# Altium schematic sheet CAN_RPi.SchDoc
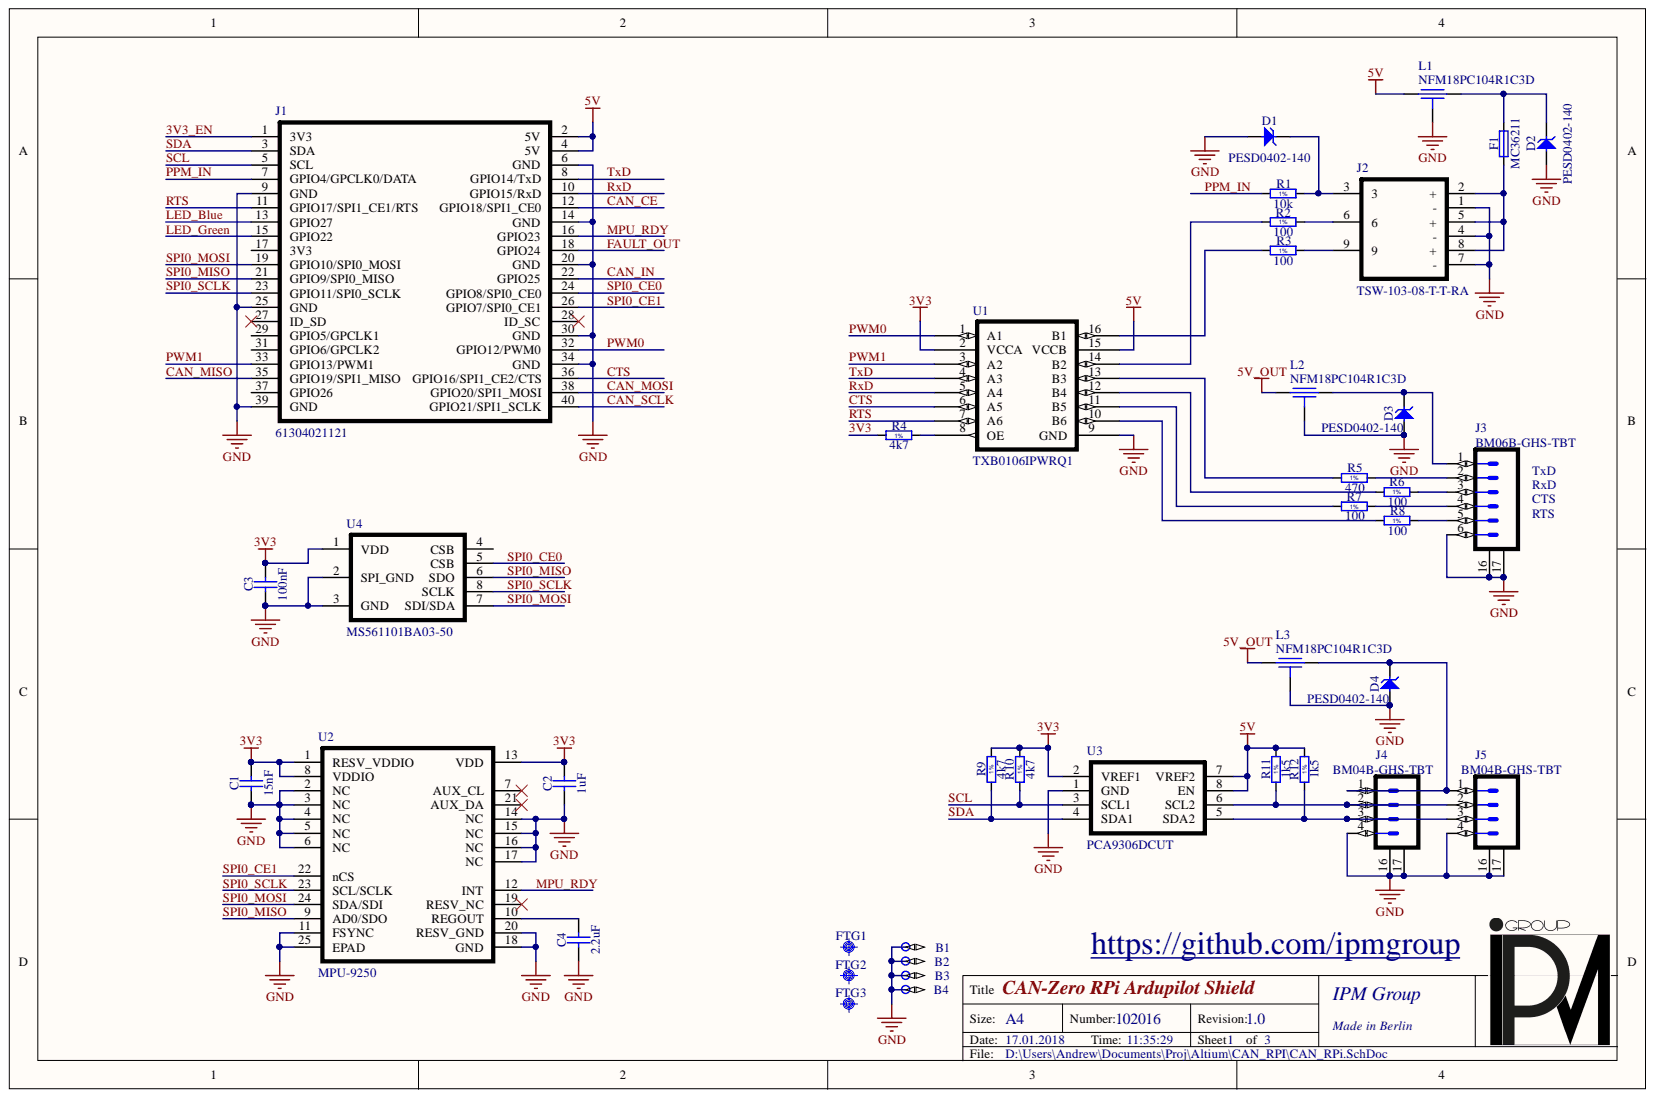
[diagram p0: CAN_RPi.SchDoc]

## Parts
B1  Plated Hole  [MTG270_500]  (value not drawn)  (no BOM)
B2  Plated Hole  [MTG270_500]  (value not drawn)  (no BOM)
B3  Plated Hole  [MTG270_500]  (value not drawn)  (no BOM)
B4  Plated Hole  [MTG270_500]  (value not drawn)  (no BOM)
C1  15nF  [CAPC1005X55N]
C2  1uF  [CAPC1005X55N]
C3  100nF  [CAPC1005X55N]
C4  2.2uF  [CAPC1005X55N]
D1  PESD0402-140  [0402]
D2  PESD0402-140  [0402]
D3  PESD0402-140  [0402]
D4  PESD0402-140  [0402]
F1  MC36211  [FUSR_LITTELFUSE_1206L012]
FTG1  Use only in PCB  [FIDUCIAL_TOP_C100-200]  (value not drawn)  (no BOM)
FTG2  Use only in PCB  [FIDUCIAL_TOP_C100-200]  (value not drawn)  (no BOM)
FTG3  Use only in PCB  [FIDUCIAL_TOP_C100-200]  (value not drawn)  (no BOM)
J1  61304021121  [61304021121_RPi]
J2  TSW-103-08-T-T-RA  [HDR3X3H]
J3  BM06B-GHS-TBT  [JST_BM06B]
J4  BM04B-GHS-TBT  [JST_BM04B]
J5  BM04B-GHS-TBT  [JST_BM04B]
L1  NFM18PC104R1C3D  [L_NFM18PC]
L2  NFM18PC104R1C3D  [L_NFM18PC]
L3  NFM18PC104R1C3D  [L_NFM18PC]
R1  10k  [RESC1005X40N]
R2  100  [RESC1005X40N]
R3  100  [RESC1005X40N]
R4  4k7  [RESC1005X40N]
R5  470  [RESC1005X40N]
R6  100  [RESC1005X40N]
R7  100  [RESC1005X40N]
R8  100  [RESC1005X40N]
R9  4k7  [RESC1005X40N]
R10  4k7  [RESC1005X40N]
R11  1k5  [RESC1005X40N]
R12  1k5  [RESC1005X40N]
U1  TXB0106IPWRQ1  [PW16]
U2  MPU-9250  [QFN40P300X300X105_HS-25M]
U3  PCA9306DCUT  [DCU8]
U4  MS561101BA03-50  [SON125P300X110-8N]

## Nets
5V: J1.2(5V) J1.4(5V) L1.1 R11.2 R12.2 U1.15(VCCB) U3.7(VREF2) U3.8(EN)
5V_OUT: L2.1 L3.1
GND: B1.1 B2.1 B3.1 B4.1 C1.1 C2.1 C3.1 C4.1 D1.2 D2.2 D3.2 D4.2 J1.6(GND) J1.9(GND) J1.14(GND) J1.20(GND) J1.25(GND) J1.30(GND) J1.34(GND) J1.39(GND) J2.1(-) J2.4(-) J2.7(-) J3.6 J3.16 J3.17 J4.4 J4.16 J4.17 J5.4 J5.16 J5.17 L1.3 L2.3 L3.3 U1.9(GND) U2.2(NC) U2.3(NC) U2.4(NC) U2.5(NC) U2.6(NC) U2.11(FSYNC) U2.14(NC) U2.15(NC) U2.16(NC) U2.17(NC) U2.18(GND) U2.20(RESV_GND) U2.25(EPAD) U3.1(GND) U4.2(SPI_GND) U4.3(GND)
3V3: C1.2 C2.2 C3.2 R4.1 R9.2 R10.2 U1.2(VCCA) U2.1(RESV_VDDIO) U2.8(VDDIO) U2.13(VDD) U3.2(VREF1) U4.1(VDD)
3V3_EN: J1.1(3V3)
CAN_CE: J1.12(GPIO18/SPI1_CE0)
CAN_IN: J1.22(GPIO25)
CAN_MISO: J1.35(GPIO19/SPI1_MISO)
CAN_MOSI: J1.38(GPIO20/SPI1_MOSI)
CAN_SCLK: J1.40(GPIO21/SPI1_SCLK)
CTS: J1.36(GPIO16/SPI1_CE2/CTS) U1.6(A5)
FAULT_OUT: J1.18(GPIO24)
LED_Blue: J1.13(GPIO27)
LED_Green: J1.15(GPIO22)
MPU_RDY: J1.16(GPIO23) U2.12(INT)
PPM_IN: J1.7(GPIO4/GPCLK0/DATA) R1.1
PWM0: J1.32(GPIO12/PWM0) U1.1(A1)
PWM1: J1.33(GPIO13/PWM1) U1.3(A2)
RTS: J1.11(GPIO17/SPI1_CE1/RTS) U1.7(A6)
RxD: J1.10(GPIO15/RxD) U1.5(A4)
SCL: J1.5(SCL) R10.1 U3.3(SCL1)
SDA: J1.3(SDA) R9.1 U3.4(SDA1)
SPI0_CE0: J1.24(GPIO8/SPI0_CE0) U4.5(CSB)
SPI0_CE1: J1.26(GPIO7/SPI0_CE1) U2.22(nCS)
SPI0_MISO: J1.21(GPIO9/SPI0_MISO) U2.9(AD0/SDO) U4.6(SDO)
SPI0_MOSI: J1.19(GPIO10/SPI0_MOSI) U2.24(SDA/SDI) U4.7(SDI/SDA)
SPI0_SCLK: J1.23(GPIO11/SPI0_SCLK) U2.23(SCL/SCLK) U4.8(SCLK)
TxD: J1.8(GPIO14/TxD) U1.4(A3)
NetC4_2: C4.2 U2.10(REGOUT)
NetD1_1: D1.1 J2.3 R1.2
NetD2_1: D2.1 F1.2 L1.2
NetD3_1: D3.1 J3.1 L2.2
NetD4_1: D4.1 J4.1 J5.1 L3.2
NetF1_1: F1.1 J2.2(+) J2.5(+) J2.8(+)
NetJ2_6: J2.6 R2.2
NetJ2_9: J2.9 R3.2
NetJ3_2: J3.2 R5.2
NetJ3_3: J3.3 R6.2
NetJ3_4: J3.4 R7.2
NetJ3_5: J3.5 R8.2
NetJ4_2: J4.2 J5.2 R11.1 U3.6(SCL2)
NetJ4_3: J4.3 J5.3 R12.1 U3.5(SDA2)
NetR2_1: R2.1 U1.14(B2)
NetR3_1: R3.1 U1.16(B1)
NetR4_2: R4.2 U1.8(OE)
NetR5_1: R5.1 U1.13(B3)
NetR6_1: R6.1 U1.12(B4)
NetR7_1: R7.1 U1.11(B5)
NetR8_1: R8.1 U1.10(B6)

Not connected: J1 17(3V3) 27(ID_SD) 28(ID_SC) 29(GPIO5/GPCLK1) 31(GPIO6/GPCLK2) 37(GPIO26); U2 7(AUX_CL) 19(RESV_NC) 21(AUX_DA); U4 4(CSB)

## Library data of the parts
B1-B4 = PLATED_HOLE2.7_PAD5.0: Single Terminal Socket; Part Description: Plated Through Hole: Hole Dia.=2.7mm Pad Dia.=5.0mm; Database Table Name: Pads; Mounted: No; ComponentHeight: 0mm; Component Type: Standard (No BOM); Family: Plated Hole; Library Name: Eletro-mechanical.DbLib; PackageDescription: Plated Through Hole: Hole Dia.=2.7mm Pad Dia.=5.0mm; Part Number: PLATED_HOLE2.7_PAD5.0; Pin Count: 1; SMD: No; Supplier 1: Farnell; Supplier 2: RSComponents; Supplier 3: Digi-Key; Author: CERN DEM JMW
C1 = CC0402_15NF_16V_10%_X7R: Non-polarised capacitor; Manufacturer: GENERIC; Manufacturer1 Part Number: MC0402B153K160CT; Part Description: SMD Multilayer Chip Ceramic Capacitor; Database Table Name: Capacitors SMD; TC: X7R; Tolerance: ±10%; Voltage: 16V; Case: 0402; Component Kind: Standard; Component Type: Standard; Library Name: Capacitors.DbLib; Manufacturer1 ComponentHeight: 0.55mm; Manufacturer1 Example: MULTICOMP; Manufacturer Part Number: CC0402_15NF_16V_10%_X7R; Mounted: Yes; Part Number: CC0402_15NF_16V_10%_X7R; Pin Count: 2; SMD: Yes; Supplier 1: Farnell; Supplier 2: RSComponents; Supplier 3: Digi-Key; Supplier Part Number 1: 1758887RL; Value: 15nF; Author: CERN DEM JLC
C2 = CC0402_1UF_16V_10%_X5R: Non-polarised capacitor; Manufacturer: GENERIC; Manufacturer1 Part Number: GRM155C80J105KE15D; Manufacturer Part Number: CC0402_1UF_6.3V_10%_X5R; Part Description: SMD Multilayer Chip Ceramic Capacitor; Database Table Name: Capacitors SMD; TC: X5R; Tolerance: ±10%; Voltage: 6.3V; Case: 0402; Component Kind: Standard; Component Type: Standard; Library Name: Capacitors.DbLib; Manufacturer1 ComponentHeight: 0.55mm; Manufacturer1 Example: MURATA; Mounted: Yes; Part Number: CC0402_1UF_6.3V_10%_X5R; Pin Count: 2; SMD: Yes; Supplier 1: Farnell; Supplier 2: RSComponents; Supplier 3: Digi-Key; Value: 1uF; Author: CERN DEM JLC
C3 = CC0402_100NF_16V_10%_X7R: Non-polarised capacitor; Manufacturer: GENERIC; Manufacturer1 Part Number: GRM155R71C104KA88D; Part Description: SMD Multilayer Chip Ceramic Capacitor; Database Table Name: Capacitors SMD; TC: X7R; Tolerance: ±10%; Voltage: 16V; Case: 0402; Component Kind: Standard; Component Type: Standard; Library Name: Capacitors.DbLib; Manufacturer1 ComponentHeight: 0.55mm; Manufacturer1 Example: MURATA; Manufacturer Part Number: CC0402_100NF_16V_10%_X7R; Mounted: Yes; Part Number: CC0402_100NF_16V_10%_X7R; Pin Count: 2; SMD: Yes; Supplier 1: Farnell; Supplier 2: RSComponents; Supplier 3: Digi-Key; Value: 100nF; Author: CERN DEM JLC
C4 = CC0402_2.2UF_6.3V_10%_X5R: Non-polarised capacitor; Manufacturer: GENERIC; Manufacturer1 Part Number: GRM155R60J225ME95D; Part Description: SMD Multilayer Chip Ceramic Capacitor; Database Table Name: Capacitors SMD; TC: X5R; Tolerance: ±10%; Voltage: 6.3V; Case: 0402; Component Kind: Standard; Component Type: Standard; Library Name: Capacitors.DbLib; Manufacturer1 ComponentHeight: 0.55mm; Manufacturer1 Example: MURATA; Manufacturer Part Number: CC0402_2.2UF_6.3V_10%_X5R; Mounted: Yes; Part Number: CC0402_2.2UF_6.3V_10%_X5R; Pin Count: 2; SMD: Yes; Supplier 1: Farnell; Supplier 2: RSComponents; Supplier 3: Digi-Key; Supplier Part Number 1: 2362091; Value: 2.2uF; Author: CERN DEM JMW
D1-D4 = PESD5V0S1UA: Transient Voltage Suppressor Diode (Uni-Directional); Manufacturer: TE CONNECTIVITY / RAYCHEM; Part Description: Unidirectional ESD Protection for Transient Voltage Suppression; Database Table Name: Diodes; Power: 890W; Voltage: 14V; Case: 0402; ComponentHeight: 1.1mm; Component Kind: Standard; Component Type: Standard; Library Name: ICs And Semiconductors.DbLib; Manufacturer1 Example: TE CONNECTIVITY / RAYCHEM; Manufacturer1 Part Number: PESD0402-140; Manufacturer Part Number: PESD0402-140; Mounted: Yes; PackageDescription: Small Outline Diode Flat Lead (SOD-323), 1.70mm W X 1.25mm L X 1.10mm H body,  IPC Medium Density; Part Number: PESD0402-140; Pin Count: 2; SMD: Yes; Supplier 1: Farnell; Supplier 2: RSComponents; Supplier 3: Digi-Key; Author: CERN DEM JLC
F1 = FUSR_LITTELFUSE_1206L012: Fuse; Manufacturer: MULTICOPM; Part Description: POLYFUSE® Surface Mount Resettable PTC; Part Number: FUSR_MULTICOPM_MC36211; Database Table Name: Fuses; Value: 350mA-16V; Case: 3518; ComponentHeight: 1.45mm; Component Kind: Standard; Component Type: Standard; Family: Fuses Resettable; Library Name: Eletro-mechanical.DbLib; Manufacturer1 Example: MULTICOPM; Manufacturer1 Part Number: MC36211; Manufacturer Part Number: MC36211; Mounted: Yes; PackageDescription: Surface Mount POLY-FUSE® Resettable PTC, 1206L Series; Pin Count: 2; SMD: Yes; Supplier 1: Farnell; Supplier 2: RSComponents; Supplier 3: Digi-Key; Supplier Part Number 1: 1861188; Author: CERN DEM JLC
FTG1-FTG3 = FIDUCIAL_TARGET_C100-200: Fiducial Target; Part Description: Round Fiducial Target, Top Layer, 1.0mm, Top Solder 2.0mm, Keepout =2.0mm; Database Table Name: Pads; Mounted: No; ComponentHeight: 0mm; Component Type: Standard (No BOM); Family: Fiducial; Library Name: Eletro-mechanical.DbLib; PackageDescription: Round Fiducial Target, Top Layer, 1.0mm, Top Solder 2.0mm, Keepout =2.0mm; Part Number: FIDUCIAL_TARGET_C100-200; Pin Count: 1; SMD: Yes; Supplier 1: Farnell; Supplier 2: RSComponents; Supplier 3: Digi-Key; Author: CERN DEM JLC
J1 = Header RPi: WR-PHD 2.54 mm THT Dual Pin Header, 40p; Manufacturer: Wurth Elektronik; Component Kind: Standard; Component Type: Standard; Library Name: My_PCB.SchLib; Manufacturer1 Example: Wurth Elektronik; Manufacturer1 Part Number: 61304021121; Manufacturer Part Number: 61304021121; Mounted: Yes; Part Description: WR-PHD 2.54 mm THT Dual Pin Header, 40p; Part Number: 61304021121; Pin Count: 40; SMD: Yes; Supplier 1: Farnell; Supplier 2: RSComponents; Supplier 3: Digi-Key
J2 = Header 3X3H: Header, 9-Pin, Right Angle; Manufacturer: SAMTEC; Part Description: THROUGH-HOLE .025" SQ POST HEADER; Component Kind: Standard; Component Type: Standard; Library Name: My_PCB.SchLib; Manufacturer1 Example: SAMTEC; Manufacturer1 Part Number: TSW-103-08-T-T-RA; Manufacturer Part Number: TSW-103-08-T-T-RA; Mounted: Yes; Part Number: TSW-103-08-T-T-RA; Pin Count: 9; SMD: Yes; Supplier 1: Farnell; Supplier 2: RSComponents; Supplier 3: Digi-Key; Supplier Part Number 1: 2053187
J3 = (no symbol name): Connector 6 Male; Manufacturer: JST; Part Description: 6 Contacts - Pitch 1.25mm; ComponentHeight: 4.05.mm; Component Kind: Standard; Component Type: Standard; Family: Header 6; Library Name: My_PCB.SchLib; Manufacturer1 Example: JST; Manufacturer1 Part Number: BM06B-GHS-TBT; Manufacturer Part Number: BM06B-GHS-TBT; Mounted: Yes; Part Number: BM06B-GHS-TBT; Pin Count: 6; SMD: Yes; Supplier 1: Farnell; Supplier 2: RSComponents; Supplier 3: Digi-Key; Supplier Part Number 2: 752-1771; Author: CERN DEM JLC
J4 J5 = JST_GH_BM04: Connector 4 Male; Manufacturer: JST; Part Description: 4 Contacts - Pitch 1.25mm; ComponentHeight: 4.05.mm; Component Kind: Standard; Component Type: Standard; Family: Header 4; Library Name: My_PCB.SchLib; Manufacturer1 Example: JST; Manufacturer1 Part Number: BM04B-GHS-TBT; Manufacturer Part Number: BM04B-GHS-TBT; Mounted: Yes; Part Number: BM04B-GHS-TBT; Pin Count: 4; SMD: Yes; Supplier 1: Farnell; Supplier 2: RSComponents; Supplier 3: Digi-Key; Supplier Part Number 2: 752-1769; Author: CERN DEM JLC
L1-L3 = L_EMI: Capacitor; Manufacturer: MURATA; Part Description: EMI Suppression Filters; RatedCurrent: 2A; Value: 100nF; Case: 0603; Component Kind: Standard; Component Type: Standard; Library Name: My_PCB.SchLib; Manufacturer1 Example: MURATA; Manufacturer1 Part Number: NFM18PC104R1C3D; Manufacturer Part Number: NFM18PC104R1C3D; Mounted: Yes; Part Number: NFM18PC104R1C3D; Pin Count: 4; SMD: Yes; Supplier 1: Farnell; Supplier 2: RSComponents; Supplier 3: Digi-Key; Supplier Part Number 1: 1686508
R1 = R0402_10K_1%_0.0625W_100PPM: Resistor - 1%; Manufacturer: GENERIC; Manufacturer1 Part Number: 232270671003L; Part Description: General Purpose Thick Film Chip Resistor; Database Table Name: Resistors SMD; TC: ±100ppm/°C; Case: 0402; Component Kind: Standard; Component Type: Standard; Library Name: Resistors.DbLib; Manufacturer1 ComponentHeight: 0.4mm; Manufacturer1 Example: YAGEO PHYCOMP; Manufacturer Part Number: R0402_10K_1%_0.0625W_100PPM; Mounted: Yes; Part Number: R0402_10K_1%_0.0625W_100PPM; Pin Count: 2; Power: 0.0625W; SMD: Yes; Supplier 1: Farnell; Supplier 2: RSComponents; Supplier 3: Digi-Key; Tolerance: ±1%; Value: 10k; Author: CERN DEM JLC
R2 R3 R6-R8 = R0402_100R_1%_0.0625W_100PPM: Resistor - 1%; Manufacturer: GENERIC; Manufacturer1 Part Number: MCWR04X1000FTL; Part Description: General Purpose Thick Film Chip Resistor; Database Table Name: Resistors SMD; TC: ±100ppm/°C; Case: 0402; Component Kind: Standard; Component Type: Standard; Library Name: Resistors.DbLib; Manufacturer1 ComponentHeight: 0.4mm; Manufacturer1 Example: MULTICOMP; Manufacturer Part Number: R0402_100R_1%_0.0625W_100PPM; Mounted: Yes; Part Number: R0402_100R_1%_0.0625W_100PPM; Pin Count: 2; Power: 0.0625W; SMD: Yes; Supplier 1: Farnell; Supplier 2: RSComponents; Supplier 3: Digi-Key; Supplier Part Number 1: 2447095; Tolerance: ±1%; Value: 100; Author: CERN DEM JLC
R4 R9 R10 = R0402_4K7_1%_0.0625W_100PPM: Resistor - 1%; Manufacturer: GENERIC; Manufacturer1 Part Number: 232270674702L; Part Description: General Purpose Thick Film Chip Resistor; Database Table Name: Resistors SMD; TC: ±100ppm/°C; Case: 0402; Component Kind: Standard; Component Type: Standard; Library Name: Resistors.DbLib; Manufacturer1 ComponentHeight: 0.4mm; Manufacturer1 Example: YAGEO PHYCOMP; Manufacturer Part Number: R0402_4K7_1%_0.0625W_100PPM; Mounted: Yes; Part Number: R0402_4K7_1%_0.0625W_100PPM; Pin Count: 2; Power: 0.0625W; SMD: Yes; Supplier 1: Farnell; Supplier 2: RSComponents; Supplier 3: Digi-Key; Tolerance: ±1%; Value: 4k7; Author: CERN DEM JLC
R5 = R0402_470R_1%_0.0625W_100PPM: Resistor - 1%; Manufacturer: GENERIC; Manufacturer1 Part Number: 232270674701L; Part Description: General Purpose Thick Film Chip Resistor; Database Table Name: Resistors SMD; TC: ±100ppm/°C; Case: 0402; Component Kind: Standard; Component Type: Standard; Library Name: Resistors.DbLib; Manufacturer1 ComponentHeight: 0.4mm; Manufacturer1 Example: YAGEO PHYCOMP; Manufacturer Part Number: R0402_470R_1%_0.0625W_100PPM; Mounted: Yes; Part Number: R0402_470R_1%_0.0625W_100PPM; Pin Count: 2; Power: 0.0625W; SMD: Yes; Supplier 1: Farnell; Supplier 2: RSComponents; Supplier 3: Digi-Key; Tolerance: ±1%; Value: 470; Author: CERN DEM JLC
R11 R12 = R0402_1K5_1%_0.0625W_100PPM: Resistor - 1%; Manufacturer: GENERIC; Manufacturer1 Part Number: 232270671502L; Part Description: General Purpose Thick Film Chip Resistor; Database Table Name: Resistors SMD; TC: ±100ppm/°C; Case: 0402; Component Kind: Standard; Component Type: Standard; Library Name: Resistors.DbLib; Manufacturer1 ComponentHeight: 0.4mm; Manufacturer1 Example: YAGEO PHYCOMP; Manufacturer Part Number: R0402_1K5_1%_0.0625W_100PPM; Mounted: Yes; Part Number: R0402_1K5_1%_0.0625W_100PPM; Pin Count: 2; Power: 0.0625W; SMD: Yes; Supplier 1: Farnell; Supplier 2: RSComponents; Supplier 3: Digi-Key; Tolerance: ±1%; Value: 1k5; Author: CERN DEM JLC
U1 = TXB0106IPWRQ1: 6-BIT  BIDIRECTIONAL  VOLTAGE-LEVEL  TRANSLATOR; Manufacturer: Texas Instruments; Manufacturer1 Part Number: TXB0106PWR; Case: TSSOP; Component Kind: Standard; Component Type: Standard; Library Name: My_PCB.SchLib; Manufacturer1 Example: Texas Instruments; Manufacturer Part Number: TXB0106PWR; Mounted: Yes; Part Description: 6-Bit Bidirectional; Part Number: TXB0106PWR; Pin Count: 16; SMD: Yes; Supplier 1: Farnell; Supplier 2: RSComponents; Supplier 3: Digi-Key; Supplier Part Number 1: 1694679
U2 = MPU-9250: Accelerometer, Gyroscope, Magnetometer, 3 Axis Sensor I²C, SPI Output; Manufacturer: invensense; Case: QFN; Component Kind: Standard; Component Type: Standard; Library Name: My_PCB.SchLib; Manufacturer1 Example: invensense; Manufacturer1 Part Number: MPU-9250; Manufacturer Part Number: MPU-9250; Mounted: Yes; Part Description: Accelerometer, Gyroscope, Magnetometer, 3 Axis Sensor I²C, SPI Output; Part Number: MPU-9250; Pin Count: 24; SMD: Yes; Supplier 1: Farnell; Supplier 2: RSComponents; Supplier 3: Digi-Key; Supplier Part Number 2: 883-7942
U3 = PCA9306DCUT: Dual Bidirectional  I2C Bus and SMBus  Voltage-Level  Translator; Manufacturer: Texas Instruments; Case: US8; Component Kind: Standard; Component Type: Standard; Library Name: My_PCB.SchLib; Manufacturer1 Example: Texas Instruments; Manufacturer1 Part Number: PCA9306DCUT; Manufacturer Part Number: PCA9306DCUT; Mounted: Yes; Part Description: Dual Bidirectional; Part Number: PCA9306DCUT; Pin Count: 8; SMD: Yes; Supplier 1: Farnell; Supplier 2: RSComponents; Supplier 3: Digi-Key; Supplier Part Number 1: 1053573; Supplier Part Number 2: 662-7421
U4 = MS561101BA03-50: Barometric Pressure Sensor; Manufacturer: MEASUREMENT SPECIALTIES; Case: QFN; Component Kind: Standard; Component Type: Standard; Library Name: My_PCB.SchLib; Manufacturer1 Example: MEASUREMENT SPECIALTIES; Manufacturer1 Part Number: MS561101BA03-50; Manufacturer Part Number: MS561101BA03-50; Mounted: Yes; Part Description: Barometric Pressure Sensor; Part Number: MS561101BA03-50; Pin Count: 8; SMD: Yes; Supplier 1: Farnell; Supplier 2: RSComponents; Supplier 3: Digi-Key; Supplier Part Number 1: 2362662
